# Altium schematic sheet ST_WIFI.SchDoc
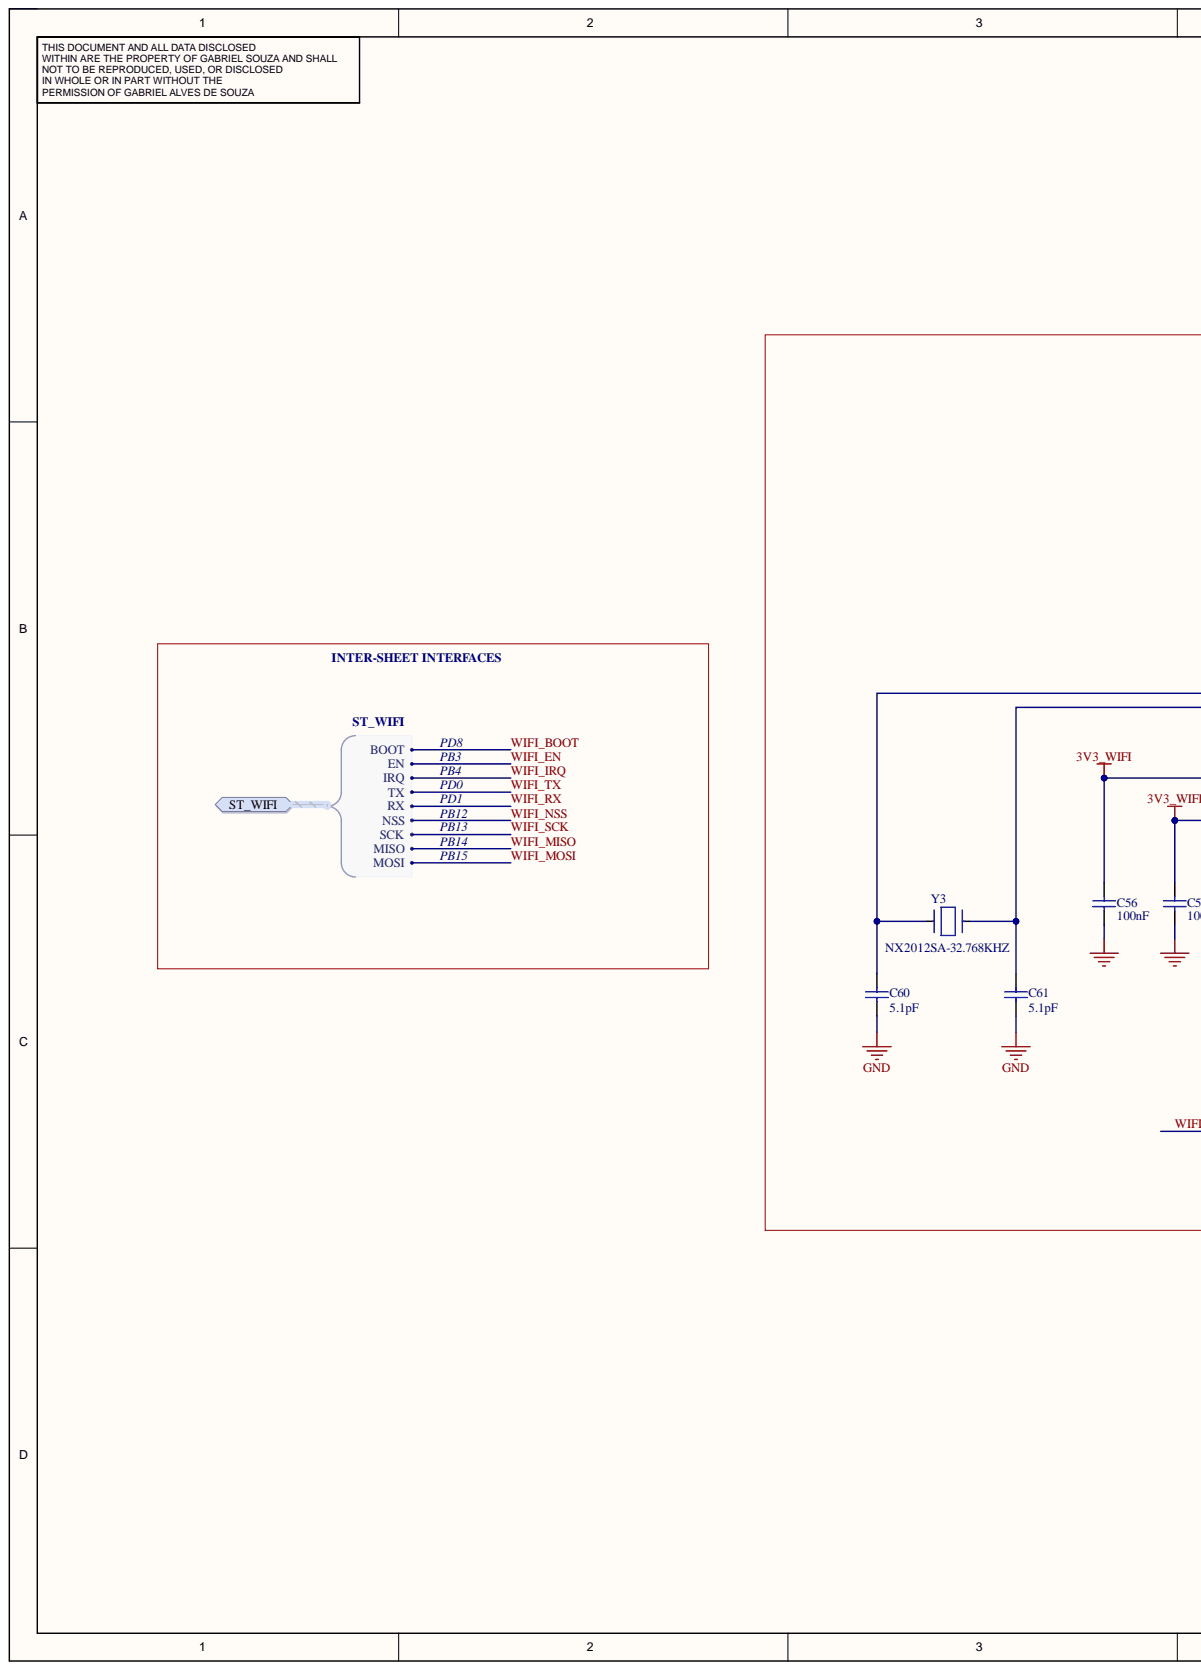
[diagram p0: ST_WIFI.SchDoc, left]
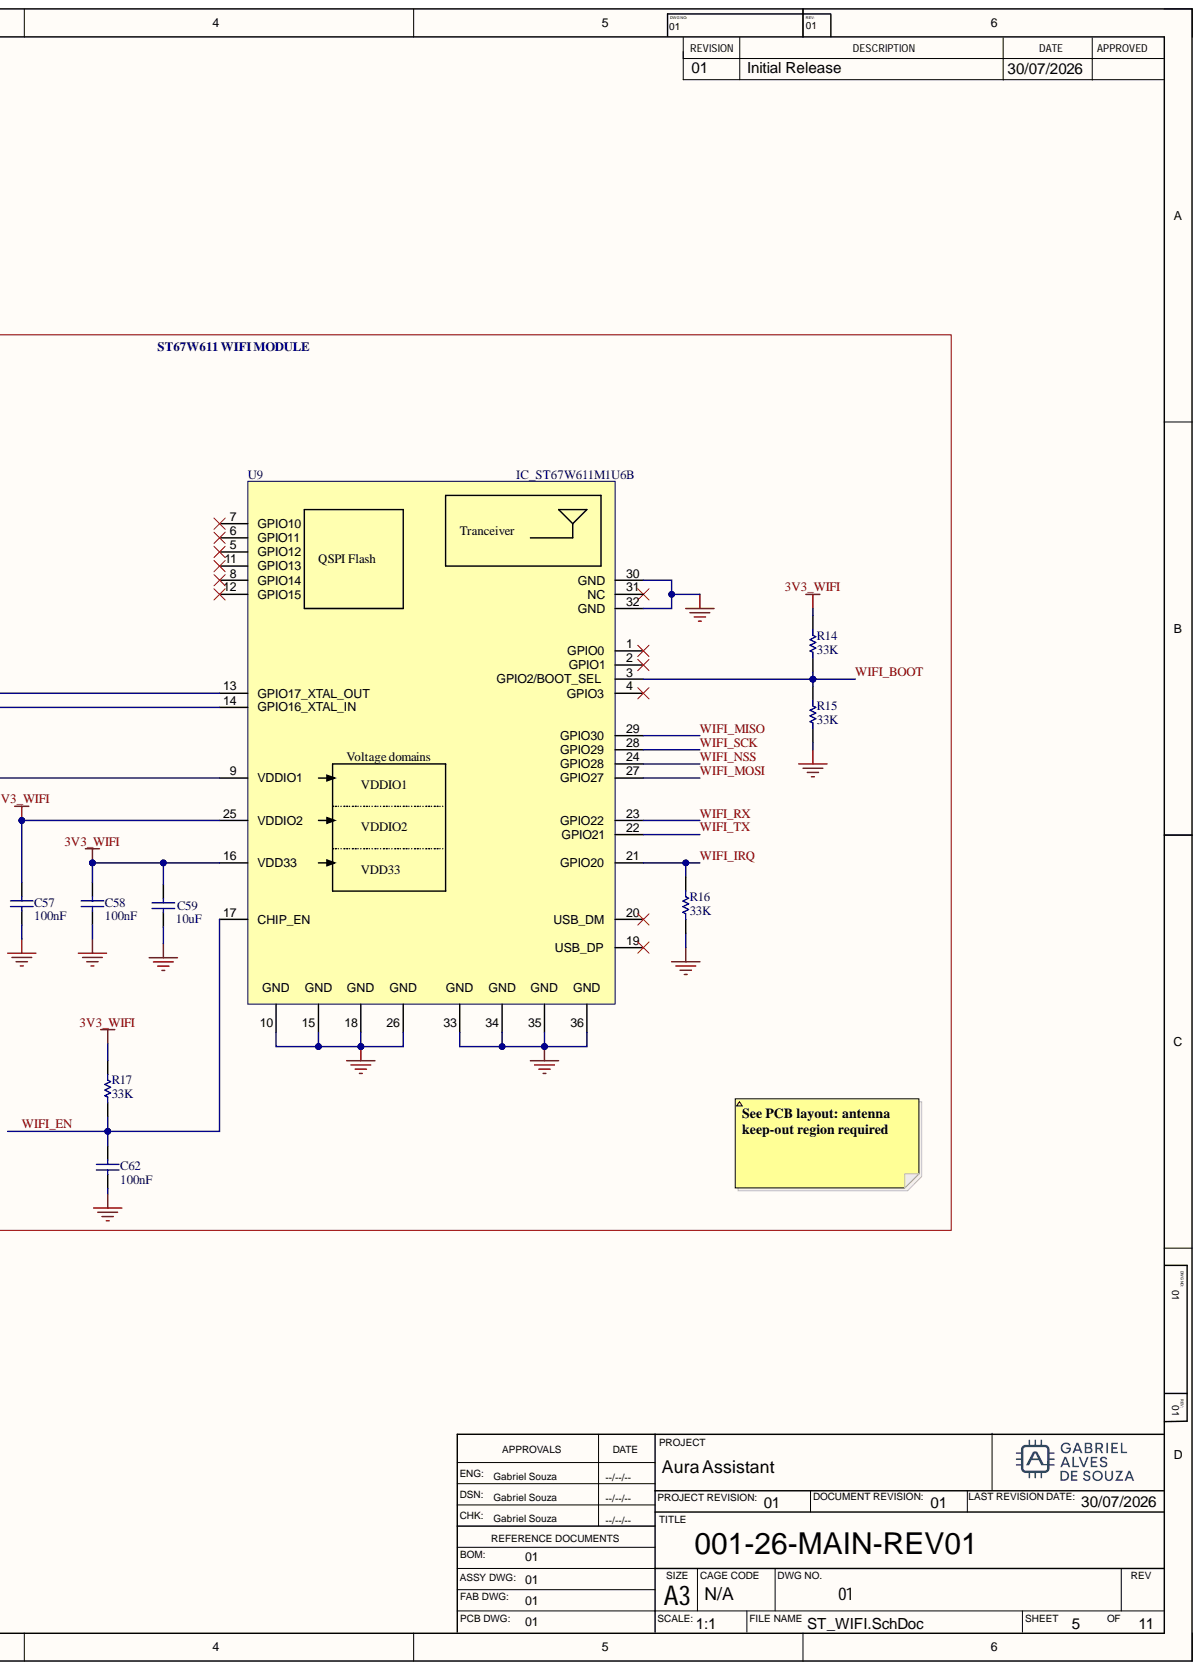
[diagram p1: ST_WIFI.SchDoc, right]

## Parts
C56  value 100nF  [C_0402_IPC_N]  (hidden comment: Capacitor)
C57  value 100nF  [C_0402_IPC_N]  (hidden comment: Capacitor)
C58  value 100nF  [C_0402_IPC_N]  (hidden comment: Capacitor)
C59  value 10uF  [C_0805_IPC_N]  (hidden comment: Capacitor)
C60  value 5.1pF  [C_0402_IPC_N]  (hidden comment: Capacitor)
C61  value 5.1pF  [C_0402_IPC_N]  (hidden comment: Capacitor)
C62  value 100nF  [C_0402_IPC_N]  (hidden comment: Capacitor)
R14  value 33K  [R_0402_IPC_N]  (hidden comment: Resistor)
R15  value 33K  [R_0402_IPC_N]  (hidden comment: Resistor)
R16  value 33K  [R_0402_IPC_N]  (hidden comment: Resistor)
R17  value 33K  [R_0402_IPC_N]  (hidden comment: Resistor)
U9  IC_ST67W611M1U6B  [MOD_QUALCOMM_QCC743M]
Y3  NX2012SA-32.768KHZ  [FP-NX2012SA-MFG]

## Ports
ST_WIFI, ST_WIFI.BOOT, ST_WIFI.EN, ST_WIFI.IRQ, ST_WIFI.MISO, ST_WIFI.MOSI, ST_WIFI.NSS, ST_WIFI.RX, ST_WIFI.SCK, ST_WIFI.TX

## Nets
3V3_WIFI: C56.2 C57.2 C58.2 C59.2 R14.1 R17.1 U9.9(VDDIO1) U9.16(VDD33) U9.25(VDDIO2)
GND: C56.1 C57.1 C58.1 C59.1 C60.1 C61.1 C62.1 R15.2 R16.2 U9.10 U9.15 U9.18 U9.26 U9.30(GND) U9.32(GND) U9.33 U9.34 U9.35 U9.36
WIFI_BOOT: R14.2 R15.1 U9.3(GPIO2/BOOT_SEL) ; port ST_WIFI
WIFI_EN: C62.2 R17.2 U9.17(CHIP_EN) ; port ST_WIFI
WIFI_IRQ: R16.1 U9.21(GPIO20) ; port ST_WIFI
WIFI_MISO: U9.29(GPIO30) ; port ST_WIFI
WIFI_MOSI: U9.27(GPIO27) ; port ST_WIFI
WIFI_NSS: U9.24(GPIO28) ; port ST_WIFI
WIFI_RX: U9.23(GPIO22) ; port ST_WIFI
WIFI_SCK: U9.28(GPIO29) ; port ST_WIFI
WIFI_TX: U9.22(GPIO21) ; port ST_WIFI
NetC60_2: C60.2 U9.13(GPIO17_XTAL_OUT) Y3.2
NetC61_2: C61.2 U9.14(GPIO16_XTAL_IN) Y3.1

Not connected: U9 1(GPIO0) 2(GPIO1) 4(GPIO3) 5(GPIO12) 6(GPIO11) 7(GPIO10) 8(GPIO14) 11(GPIO13) 12(GPIO15) 19(USB_DP) 20(USB_DM) 31(NC)

## Library data of the parts
C56-C58 C62 = CAP: Capacitor, MLCC, 100nF, 16V, X7R, +/-10%, 0402, T=0,5mm; Manufacturer: TDK; Manufacturer_Part_Number: C1005X7R1C104KT000F; Component Kind: Standard; ComponentLink1Description: Datasheet; ComponentLink1URL: https://www.lcsc.com/datasheet/C338032.pdf?spm=wm.sxq.inf.ggs___wm.fly.bg.6.xh&lcsc_vid=TlIKVlcFRVJWVl1WQVFfBlQDRFgPAVVQRFhaBQIFFgQxVlNeRVRbU1RQQ1dWUTtW; Order_Code_LCSC: C338032; Package: 0402; Library Name: Gabriel_Lib.IntLib
C59 = CAP: Capacitor, MLCC, 10uF, 16V, X5R, +/-10%, 0805, T=0,5mm; Manufacturer: muRata; Manufacturer_Part_Number: GRM21BR61C106KE15K; Component Kind: Standard; ComponentLink1Description: Datasheet; ComponentLink1URL: https://www.lcsc.com/datasheet/C338032.pdf?spm=wm.sxq.inf.ggs___wm.fly.bg.6.xh&lcsc_vid=TlIKVlcFRVJWVl1WQVFfBlQDRFgPAVVQRFhaBQIFFgQxVlNeRVRbU1RQQ1dWUTtW; Order_Code_LCSC: C408141; Package: 0805; Library Name: Gabriel_Lib.IntLib
C60 C61 = CAP: Capacitor, MLCC, 5.1pF, 50V, C0G, +/-10%, 0402, T=0,5mm; Manufacturer: muRata; Manufacturer_Part_Number: GJM1555C1H5R1WB01D; Component Kind: Standard; ComponentLink1Description: Datasheet; ComponentLink1URL: https://www.lcsc.com/datasheet/C338032.pdf?spm=wm.sxq.inf.ggs___wm.fly.bg.6.xh&lcsc_vid=TlIKVlcFRVJWVl1WQVFfBlQDRFgPAVVQRFhaBQIFFgQxVlNeRVRbU1RQQ1dWUTtW; Order_Code_LCSC: C437506; Package: 0402; Library Name: Gabriel_Lib.IntLib
R14-R17 = RES: Resistor, 33K, 0402, 0.1%, 62.5mW; Manufacturer: YAGEO; Manufacturer_Part_Number: RT0402BRD0733KL; Component Kind: Standard; ComponentLink1Description: Datasheet; Order_Code_LCSC: C728559; Package: 0402; Part_Type: Resistor; Library Name: Gabriel_Lib.IntLib
U9 = MCD-04201: Module, 2.4GHz, Wi-Fi 6, Bluetooth, 802.15.4 combo; Manufacturer: STMICROELECTRONICS; Manufacturer_Part_Number: ST67W611M1A6B; Part_Preference: Recommended; Part_Type: IC; Component_Country_Of_Origin: Unknown; Database Table Name: MCD_PARTS$; ID: 6317; ShowFields: YES; Value: ST67W611M1A6B; Component Kind: Standard; ComponentLink1URL: http://tunmcdsvn.tun.st.com/Component_Documentation_Library/Trunk/IC/STMICROELECTRONICS_STM32WW6_Series; Internal_Part_Number: MCD-04201; Library Name: MCD_PARTS_database.DbLib; Order_Code_Digikey: N/A; Order_Code_Farnell: N/A; Order_Code_LCSC: C47341702; Package: LGA-36; Status: Released
Y3 = CMP-200220-000005-1: CRYSTAL 32.768KHZ 7PF SMD; Manufacturer: NDK America; Manufacturer Part Number: NX2012SA-32.768KHZ-EXS00A-MU00389; Esr: 80kΩ; Load Capacitance: 12.5pF; Tolerance: 20ppm; Automotive: No; Category: Crys; Component Kind: Standard; ComponentLink2Description: Datasheet; ComponentLink2URL: https://www.ndk.com/images/products/catalog/c_NX2012SA-STD-MUB-1_e.pdf; Device Class L1: Passive Components; Device Class L2: Crystals / Oscillators; Device Class L3: Crystals; Digikey Description: CRYSTAL 32.768KHZ 7PF SMD; Frequency: 32.768kHz; Height: 0.6mm; Lead Free: Yes; Library Name: Altium Content Vault; Octopart: https://octopart.com/nx2012sa-32.768khz-exs00a-mu00389-ndk-92010294; Order_Code_LCSC: C889705; Package: NX2012SA; RoHS: TRUE; Series: NX2012SA; Temperature: -40°C to +85°C; Temperature Range High: +85°C; Temperature Range Low: -40°C
